# Altium schematic sheet OLED.SchDoc(OLED)
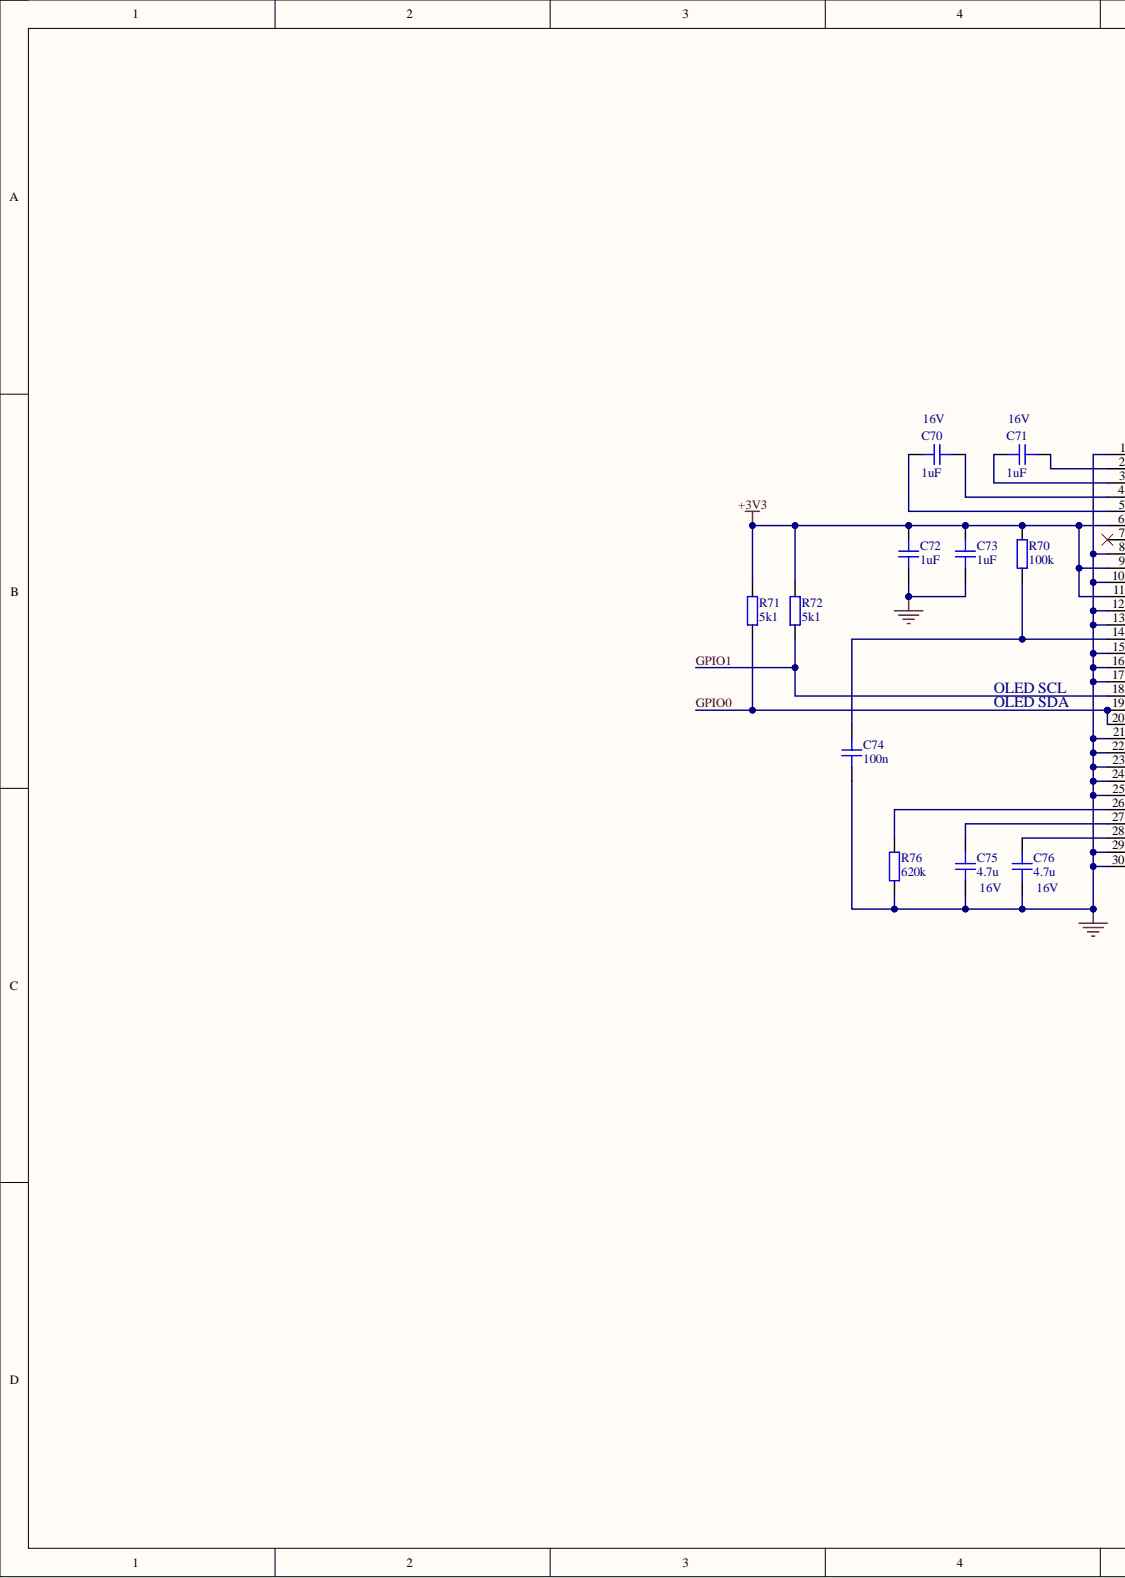
[diagram p0: OLED.SchDoc, left]
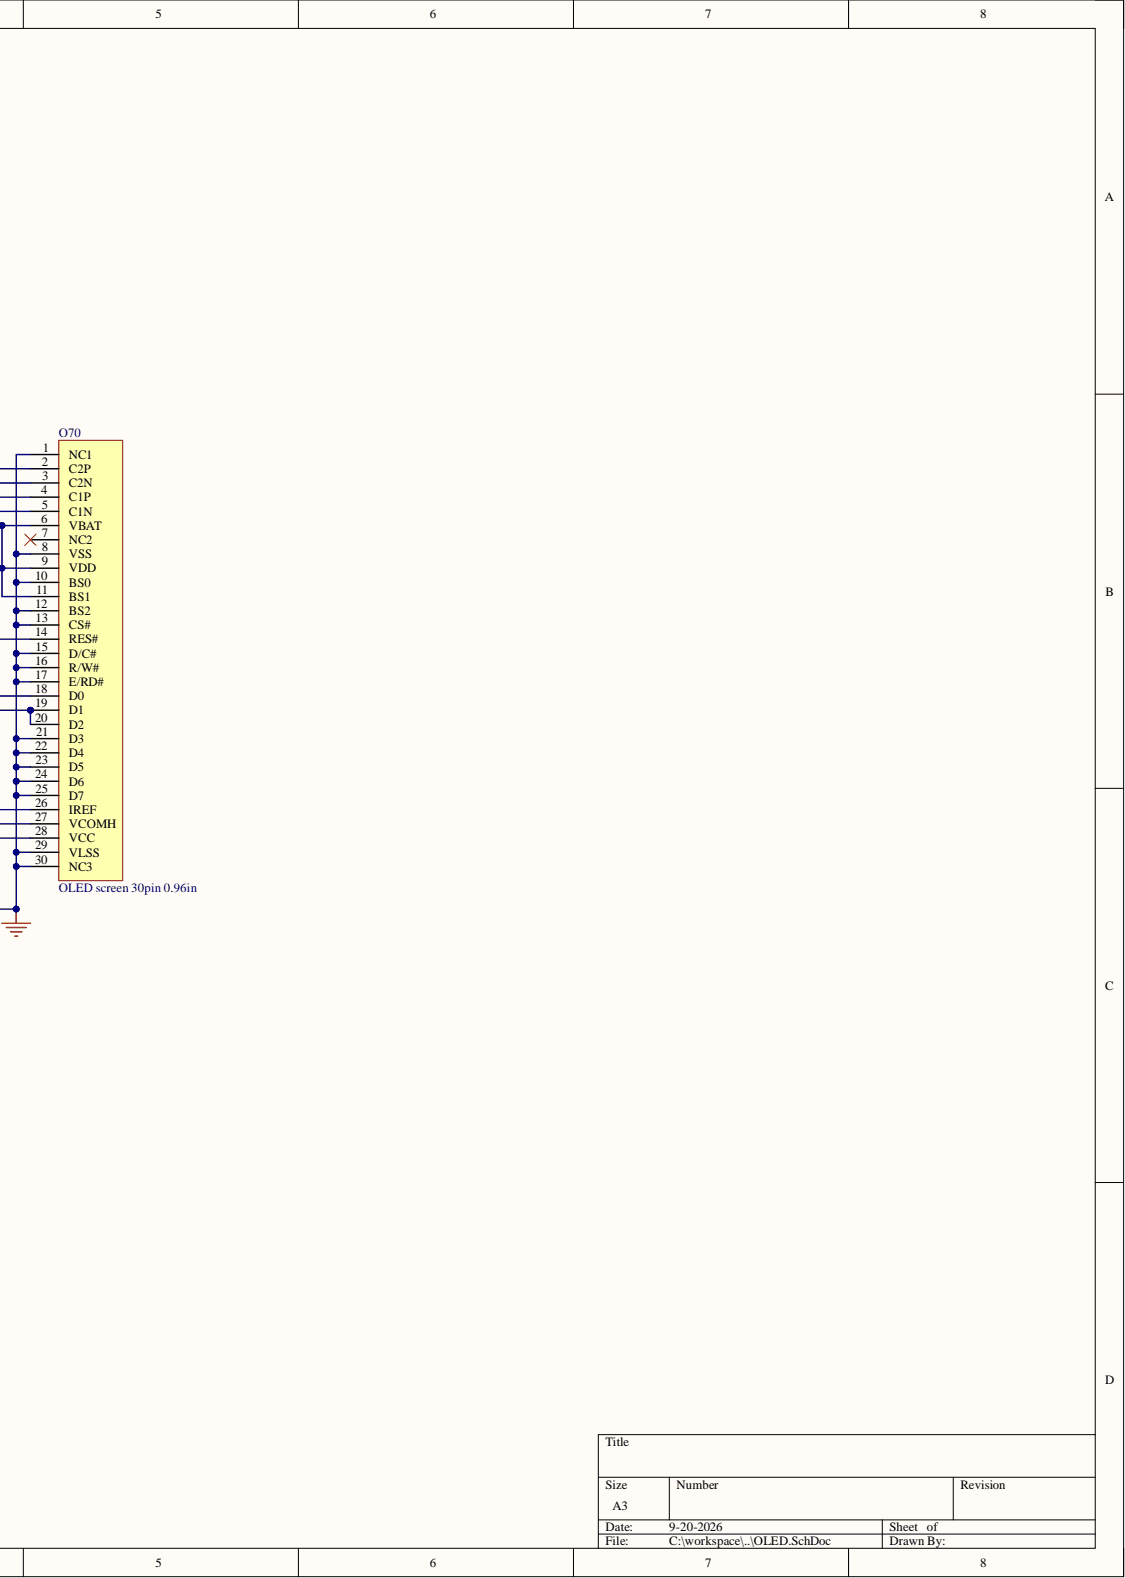
[diagram p1: OLED.SchDoc, right]

## Parts
C70  1uF  [CAP_0402]
C71  1uF  [CAP_0402]
C72  1uF  [CAP_0402]
C73  1uF  [CAP_0402]
C74  100n  [CAP_0402]
C75  4.7u  [CAP_0603]
C76  4.7u  [CAP_0603]
O70  OLED screen 30pin 0.96in  [N096-2864KSWEG01-H30]
R70  100k  [RES_0402]
R71  5k1  [RES_0402]
R72  5k1  [RES_0402]
R76  620k  [RES_0402]

## Nets
+3V3: C72.1 C73.1 O70.6(VBAT) O70.9(VDD) O70.11(BS1) R70.1 R71.1 R72.1
GND: C72.2 C73.2 C74.1 C75.1 C76.1 O70.1(NC1) O70.8(VSS) O70.10(BS0) O70.12(BS2) O70.13(CS#) O70.15(D/C#) O70.16(R/W#) O70.17(E/RD#) O70.21(D3) O70.22(D4) O70.23(D5) O70.24(D6) O70.25(D7) O70.29(VLSS) O70.30(NC3) R76.2
GPIO0: O70.19(D1) O70.20(D2) R71.2
GPIO1: O70.18(D0) R72.2
NetC70_1: C70.1 O70.4(C1P)
NetC70_2: C70.2 O70.5(C1N)
NetC71_1: C71.1 O70.2(C2P)
NetC71_2: C71.2 O70.3(C2N)
NetC74_2: C74.2 O70.14(RES#) R70.2
NetC75_2: C75.2 O70.27(VCOMH)
NetC76_2: C76.2 O70.28(VCC)
NetO70_26: O70.26(IREF) R76.1

Not connected: O70 7(NC2)

## Library data of the parts
C70-C73 = CAP_0402_50V: Capacitor SMD 0402 50V 1uF; Manufacturer: Samsung; Manufacturer Part Number: CL05A105KA5NQNC; Component Kind: Standard; LCSC: C52923; Library Name: Schematic-Lib-PhilsLab.SchLib
C74 = CAP_0402_50V: Capacitor SMD 0402 50V; Manufacturer: Samsung; Manufacturer Part Number: CL05B104KO5NNNC; Component Kind: Standard; LCSC: C1525; Library Name: Schematic-Lib-PhilsLab.SchLib
C75 C76 = CAP_0603_10V: Capacitor SMD 0603 10V; Manufacturer: Samsung; Manufacturer Part Number: CL10A475KO8NNNC; Component Kind: Standard; LCSC: C19666; Library Name: Schematic-Lib-PhilsLab.SchLib
O70 = N096-2864KSWEG01-H30: SSD1306 0.96 - OLED Display ROHS; Manufacturer: Newvisio; Product name: X096-2864KSWPG01-H30; Component Kind: Standard; LCSC: C18723026; Supplier: JLCPCB; Library Name: BSides.SCHLIB
R70 = RES_0402: Resistor SMD 0402 100k; Manufacturer: Uniroyal; Manufacturer Part Number: 0402WGF1003TCE; Component Kind: Standard; LCSC: C25741; Library Name: Schematic-Lib-PhilsLab.SchLib
R71 R72 = RES_0402: Resistor SMD 0402 5.1k; Manufacturer: Uniroyal; Manufacturer Part Number: 0402WGF5101TCE; Component Kind: Standard; LCSC: C25905; Library Name: Schematic-Lib-PhilsLab.SchLib
R76 = RES_0402: Resistor SMD 0402 620k; Manufacturer: Uniroyal; Manufacturer Part Number: 0402WGF6203TCE; Component Kind: Standard; LCSC: C270590; Library Name: Schematic-Lib-PhilsLab.SchLib
